# Altium schematic sheet CAN.SchDoc
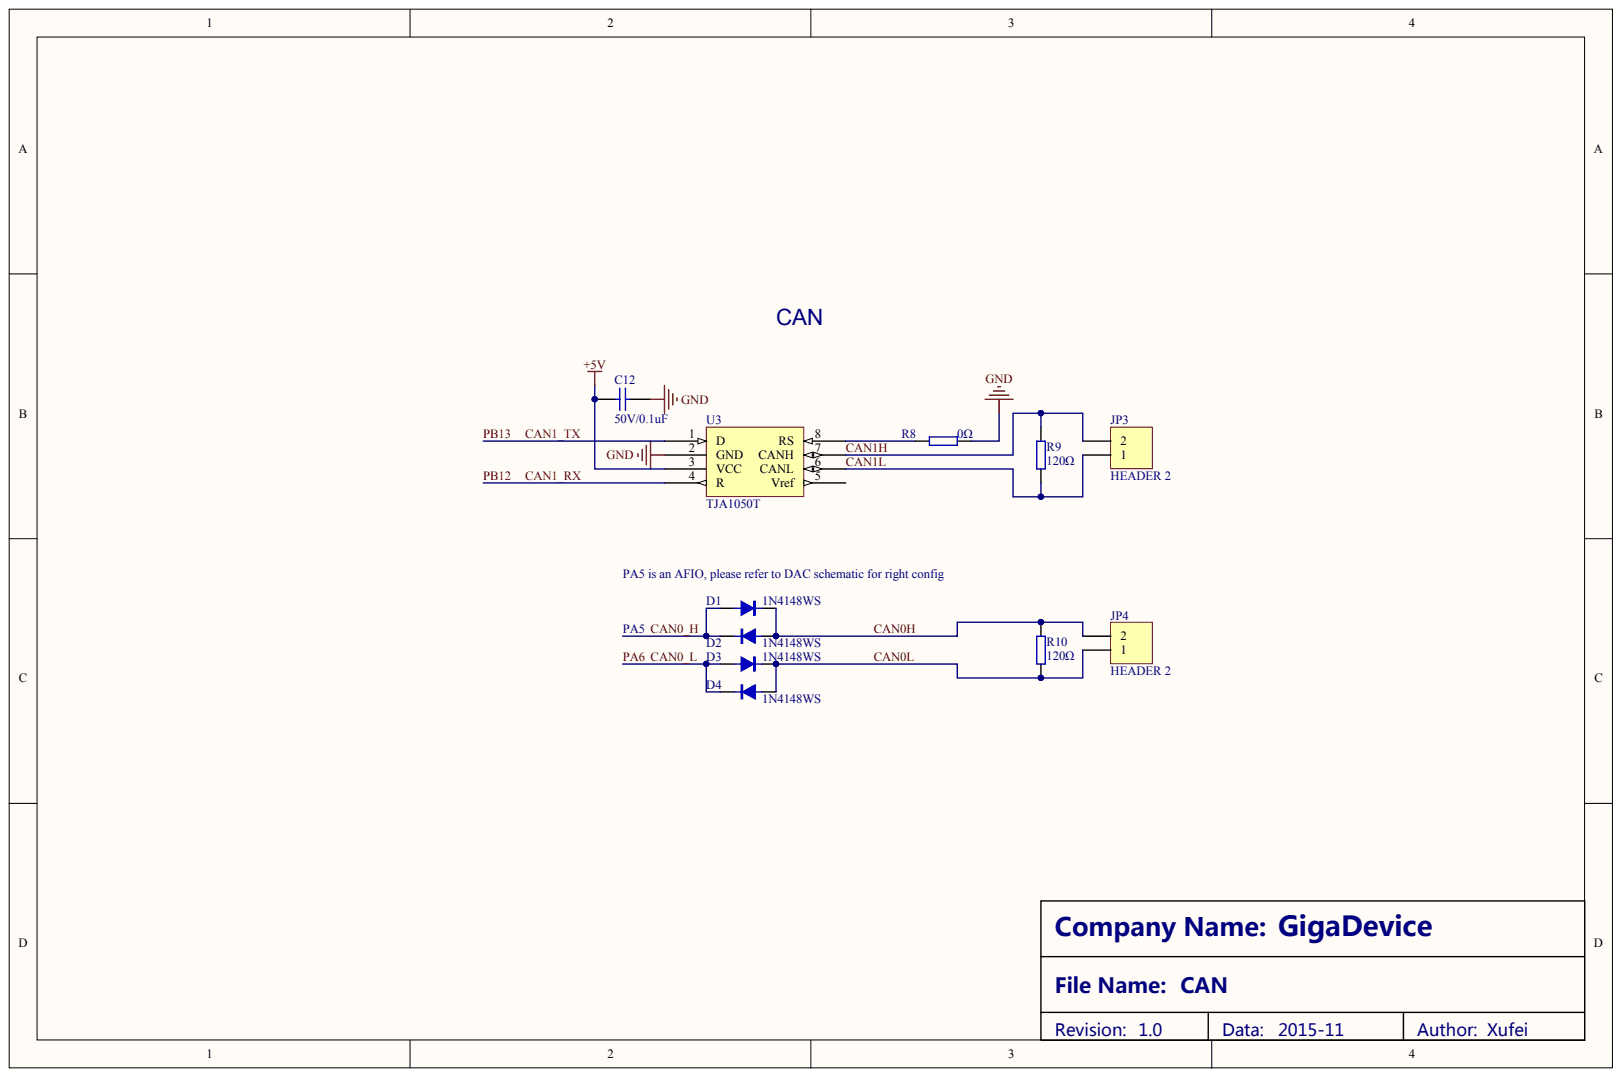
[diagram p0: CAN.SchDoc]

## Parts
C12  50V/0.1uF
D1  1N4148WS
D2  1N4148WS
D3  1N4148WS
D4  1N4148WS
JP3  HEADER 2
JP4  HEADER 2
R8  0Ω
R9  120Ω
R10  120Ω
U3  TJA1050T

## Nets
+5V: C12.1 U3.3(VCC)
GND: C12.2 R8.1 U3.2(GND)
CAN0_H: D1.A D2.K
CAN0_L: D3.A D4.K
CAN0H: D1.K D2.A JP4.2 R10.2
CAN0L: D3.K D4.A JP4.1 R10.1
CAN1_RX: U3.4(R)
CAN1_TX: U3.1(D)
CAN1H: JP3.2 R9.2 U3.7(CANH)
CAN1L: JP3.1 R9.1 U3.6(CANL)
NetR8_2: R8.2 U3.8(RS)

Not connected: U3 5(Vref)
